# Altium schematic sheet Microcontroller_STM32F04.SchDoc
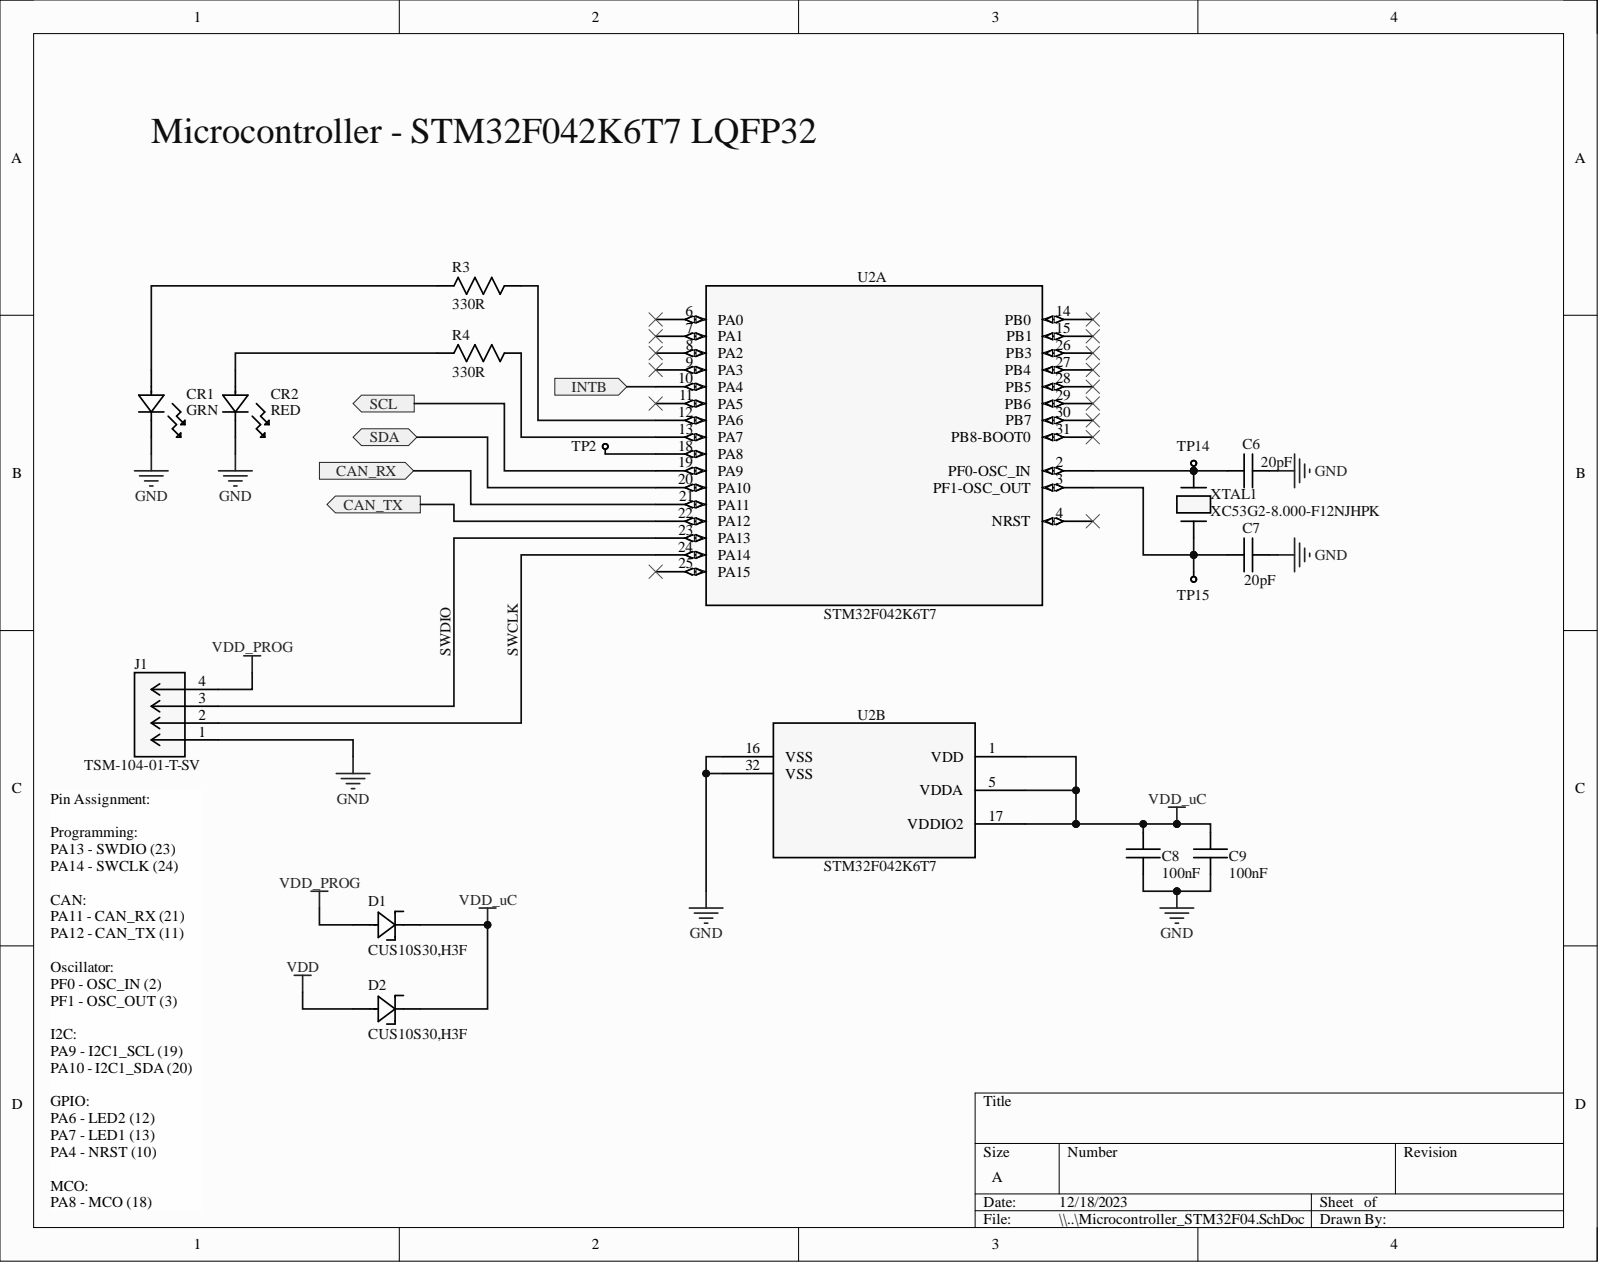
[diagram p0: Microcontroller_STM32F04.SchDoc]

## Parts
C6  20pF  [C0603]
C7  20pF  [C0603]
C8  100nF  [CAP0805]
C9  100nF  [CAP0805]
CR1  GRN  [LED_VISHAY_VLMX1300_VIS]
CR2  RED  [LED_VISHAY_VLMX1300_VIS]
D1  CUS10S30,H3F  [USC_TOS]
D2  CUS10S30,H3F  [USC_TOS]
J1  TSM-104-01-T-SV  [CONN_TSM-104-01-T-SV_SAI]
R3  330R  [R0603]
R4  330R  [R0603]
TP2  1mm Test Point  [TP_1MM]  (value not drawn)
TP14  1mm Test Point  [TP_1MM]  (value not drawn)
TP15  1mm Test Point  [TP_1MM]  (value not drawn)
U2  STM32F042K6T7  [LQFP-32]  (parts A,B)
XTAL1  XC53G2-8.000-F12NJHPK  [XTAL_NX5032_NDK]

## Ports
CAN_RX, CAN_TX, INTB, SCL, SDA

## Nets
GND: C6.1 C7.1 C8.2 C9.2 CR1.1 CR2.1 J1.1 U2.16(VSS) U2.32(VSS)
VDD: D2.2
VDD_PROG: D1.2 J1.4
VDD_uC: C8.1 C9.1 D1.1 D2.1 U2.1(VDD) U2.5(VDDA) U2.17(VDDIO2)
CAN_RX: U2.21(PA11) ; port CAN_RX
CAN_TX: U2.22(PA12) ; port CAN_TX
INTB: U2.10(PA4) ; port INTB
SCL: U2.19(PA9) ; port SCL
SDA: U2.20(PA10) ; port SDA
NetC6_2: C6.2 TP14.1 U2.2(PF0-OSC_IN) XTAL1.2
NetC7_2: C7.2 TP15.1 U2.3(PF1-OSC_OUT) XTAL1.1
NetCR1_2: CR1.2 R3.2
NetCR2_2: CR2.2 R4.2
NetJ1_2: J1.2 U2.24(PA14)
NetJ1_3: J1.3 U2.23(PA13)
NetR3_1: R3.1 U2.12(PA6)
NetR4_1: R4.1 U2.13(PA7)
NetTP2_1: TP2.1 U2.18(PA8)

Not connected: U2 4(NRST) 6(PA0) 7(PA1) 8(PA2) 9(PA3) 11(PA5) 14(PB0) 15(PB1) 25(PA15) 26(PB3) 27(PB4) 28(PB5) 29(PB6) 30(PB7) 31(PB8-BOOT0)

## Library data of the parts
C6 C7 = CL10C200JB8NNNC: Multilayer Ceramic Capacitor, 20 pF, 50 V, ± 5%, C0G (NP0), 0603 [1608 Metric]; Manufacturer: Samsung; Temperature Coefficient: 300ppm/°C; Value: 20pF 50V; Voltage: 50V; Case Code (Imperial): 0603; Case Code (Metric): 1608; Case/Package: 0603; Category: Ceramic Capacitors; Component Kind: Standard; ComponentLink1Description: https://datasheet.ciiva.com/26877/getdatasheetpartid-640634-26877974.pdf?src-supplier=Verical; ComponentLink1URL: https://datasheet.ciiva.com/26877/getdatasheetpartid-640634-26877974.pdf?src-supplier=Verical; ComponentLink2Description: https://datasheet.ciiva.com/26310/mlcc-catalogdownload2013-eng-26310315.pdf?src-supplier=Distrelec; ComponentLink2URL: https://datasheet.ciiva.com/26310/mlcc-catalogdownload2013-eng-26310315.pdf?src-supplier=Distrelec; Construction: Flat; Depth: 0.8mm; Dielectric: C0G; Height: 0.8mm; LCSC Part#: C1648; Length: 1.6mm; Library Name: Schlib1.SchLib; Manufacturer Part Number: CL10C200JB8NNNC; Max Operating Temperature: 125°C; Min Operating Temperature: -55°C; Mounting Technology: Surface Mount; Package Quantity: 1; Packaging: Tape and Reel; Pricing: 1=0.1, 10=0.041, 50=0.0238, 100=0.0184, 500=0.01386, 1000=0.01089 (USD); Radiation Hardening: No; RoHS: Yes; RoHS Compliant: Yes; Series: CL; Stock: 379574; Supplier: Digi-Key; Supplier Part Number: 1276-1187-1-ND; Thickness: 0.035inch; Tolerance: 5%; Voltage Rating: 50V; Voltage Rating (DC): 50V; Width: 0.031inch
C8 C9 = CC0805KRX7R9BB104: Multilayer Ceramic Capacitor, 0.1 uF, 50 V, ± 10%, X7R, 0805 [2012 Metric]; Manufacturer: Yageo; Insulation Resistance: 100GR; Value: 100nF 50V; Voltage: 50V; Case Code (Imperial): 0805; Case Code (Metric): 2012; Case/Package: 0805; Category: Ceramic Capacitors; Component Kind: Standard; ComponentLink1Description: https://datasheet.ciiva.com/11786/0900766b80d84f1d-11786009.pdf?src-supplier=Rs; ComponentLink1URL: https://datasheet.ciiva.com/11786/0900766b80d84f1d-11786009.pdf?src-supplier=Rs; ComponentLink2Description: https://datasheet.ciiva.com/46393/1734573-46393307.pdf?src-supplier=Element14; ComponentLink2URL: https://datasheet.ciiva.com/46393/1734573-46393307.pdf?src-supplier=Element14; ComponentLink3Description: https://datasheet.ciiva.com/155/mlccorderingcode-155930.pdf?src-supplier=Digi-Key; ComponentLink3URL: https://datasheet.ciiva.com/155/mlccorderingcode-155930.pdf?src-supplier=Digi-Key; ComponentLink4Description: https://datasheet.ciiva.com/155/mlccorderingcode-155929.pdf?src-supplier=Digi-Key; ComponentLink4URL: https://datasheet.ciiva.com/155/mlccorderingcode-155929.pdf?src-supplier=Digi-Key; ComponentLink5Description: https://datasheet.ciiva.com/155/mlccorderingcode-155931.pdf?src-supplier=Digi-Key; ComponentLink5URL: https://datasheet.ciiva.com/155/mlccorderingcode-155931.pdf?src-supplier=Digi-Key; ComponentLink6Description: https://datasheet.ciiva.com/11464/upy-gphc-x7r-6-3v-to-50v-8-11464757.pdf?src-supplier=Avnet; ComponentLink6URL: https://datasheet.ciiva.com/11464/upy-gphc-x7r-6-3v-to-50v-8-11464757.pdf?src-supplier=Avnet; ComponentLink7Description: https://datasheet.ciiva.com/28450/1489800-28450805.pdf?src-supplier=Element14; ComponentLink7URL: https://datasheet.ciiva.com/28450/1489800-28450805.pdf?src-supplier=Element14; ComponentLink8Description: https://datasheet.ciiva.com/29242/445210-da-01-en-kerko-chip-0805-x7r-0-1uf-10proz-50vg-29242074.pdf?src-supplier=Conrad; ComponentLink8URL: https://datasheet.ciiva.com/29242/445210-da-01-en-kerko-chip-0805-x7r-0-1uf-10proz-50vg-29242074.pdf?src-supplier=Conrad; Depth: 1.25mm; Dielectric: X7R; Halogen Free: Halogen Free; Height: 0.85mm; LCSC Part#: C49678; Lead Free: Lead Free; Length: 2mm; Library Name: Schlib1.SchLib; Manufacturer Part Number: CC0805KRX7R9BB104; Material: Ceramic; Max Operating Temperature: 125°C; Min Operating Temperature: -55°C; Mounting Technology: Surface Mount; Package Quantity: 4000; Packaging: Tape and Reel; Pins: 2; Pricing: 1=0.1, 10=0.07, 50=0.0418, 100=0.0329, 500=0.02428, 1000=0.0201 (USD); Radiation Hardening: No; Reach SVHC Compliant: No; RoHS: Yes; RoHS Compliant: Yes; Series: CC; Stock: 6426664; Supplier: Digi-Key; Supplier Part Number: 311-1140-1-ND; Termination: SMD/SMT; Tolerance: 10%; Voltage Rating: 50V
CR1 = VLMG1300-GS08: LED Uni-Color Yellow Green 574nm 2-Pin Chip 0603(1608Metric) T/R; Manufacturer: Vishay Semiconductors; Mfr_Name: Vishay; Color: Green,Yellow; Dominant Wavelength: 571nm; Forward Current: 20mA; Forward Voltage: 2V; Lens Style: Rectangular,Clear; Luminous Intensity: 35mcd; Number of Elements: 1; Power Dissipation: 75mW; Reverse Voltage: 5V; Type: DEV; Value: LED Green; Viewing Angle: 130°; Case Code (Imperial): 0603; Case Code (Metric): 1608; Case/Package: 0603; Category: LEDs; Component Kind: Standard; ComponentLink1Description: https://datasheet.ciiva.com/26322/vlmo1300-26322139.pdf?src-supplier=Element14; ComponentLink1URL: https://datasheet.ciiva.com/26322/vlmo1300-26322139.pdf?src-supplier=Element14; ComponentLink2Description: https://datasheet.ciiva.com/26322/vlmo1300-26322140.pdf?src-supplier=Element14; ComponentLink2URL: https://datasheet.ciiva.com/26322/vlmo1300-26322140.pdf?src-supplier=Element14; ComponentLink3Description: https://datasheet.ciiva.com/8028/vlmo1300-8028023.pdf?src-supplier=Element14; ComponentLink3URL: https://datasheet.ciiva.com/8028/vlmo1300-8028023.pdf?src-supplier=Element14; ComponentLink4Description: https://datasheet.ciiva.com/9333/vlmo1300-9333390.pdf?src-supplier=Avnet; ComponentLink4URL: https://datasheet.ciiva.com/9333/vlmo1300-9333390.pdf?src-supplier=Avnet; Depth: 0.8mm; Height: 0.8mm; Illumination Color: Green,Yellow; LCSC Part#: C4749244; Lead Free: Lead Free; Length: 1.6mm; Library Name: Schlib1.SchLib; Manufacturer Part Number: VLMG1300-GS08; Manufacturer_Part_Number: VLMG1300-GS08; Max Operating Temperature: 85°C; Min Operating Temperature: -35°C; Mounting Technology: Surface Mount; Number of LEDs: 1; Package Quantity: 1; Packaging: Tape and Reel; Pins: 2; Power Rating: 75mW; Pricing: 1=0.3, 10=0.237, 100=0.1065, 500=0.09616, 1000=0.07898 (USD); Radiation Hardening: No; Reach SVHC Compliant: Unknown; RefDes: RefDes; RoHS: Yes; RoHS Compliant: Yes; Series: CHipLED; Stock: 55201; Supplier: Digi-Key; Supplier Part Number: VLMG1300-GS08CT-ND; Test Current: 20mA; Wavelength: 571nm; Width: 0.8mm; Copyright: Copyright (C) 2023 Ultra Librarian. All rights reserved.
CR2 = VLMS1300-GS08: LED RED 0603 SMD; Manufacturer: Vishay Semiconductors; Mfr_Name: Vishay; Dominant Wavelength: 631nm; Forward Current: 20mA; Forward Voltage: 2V; Lens Style: Rectangular,Clear; Luminous Intensity: 54mcd; Number of LEDs: 1; Power Rating: 75mW; Type: DEV; Value: LED Red; Viewing Angle: 130°; Case Code (Imperial): 0603; Case Code (Metric): 1608; Case/Package: 0603; Category: LEDs; Color: Red; Component Kind: Standard; ComponentLink1Description: https://datasheet.ciiva.com/26322/vlmo1300-26322153.pdf?src-supplier=Element14; ComponentLink1URL: https://datasheet.ciiva.com/26322/vlmo1300-26322153.pdf?src-supplier=Element14; ComponentLink2Description: https://datasheet.ciiva.com/8028/vlmo1300-8028047.pdf?src-supplier=Element14; ComponentLink2URL: https://datasheet.ciiva.com/8028/vlmo1300-8028047.pdf?src-supplier=Element14; ComponentLink3Description: https://datasheet.ciiva.com/9333/vlmo1300-9333743.pdf?src-supplier=Avnet; ComponentLink3URL: https://datasheet.ciiva.com/9333/vlmo1300-9333743.pdf?src-supplier=Avnet; ComponentLink4Description: https://datasheet.ciiva.com/16510/vlmo1300-16510985.pdf?src-supplier=Future+Electronics; ComponentLink4URL: https://datasheet.ciiva.com/16510/vlmo1300-16510985.pdf?src-supplier=Future+Electronics; ComponentLink5Description: https://datasheet.ciiva.com/2114/vlmo1300-2114930.pdf?src-supplier=Digi-Key; ComponentLink5URL: https://datasheet.ciiva.com/2114/vlmo1300-2114930.pdf?src-supplier=Digi-Key; ComponentLink6Description: https://datasheet.ciiva.com/2114/vlmo1300-2114931.pdf?src-supplier=Digi-Key; ComponentLink6URL: https://datasheet.ciiva.com/2114/vlmo1300-2114931.pdf?src-supplier=Digi-Key; ComponentLink7Description: https://datasheet.ciiva.com/2114/vlmo1300-2114929.pdf?src-supplier=Digi-Key; ComponentLink7URL: https://datasheet.ciiva.com/2114/vlmo1300-2114929.pdf?src-supplier=Digi-Key; Height: 0.8mm; Illumination Color: Red; LCSC Part#: C83242; Lead Free: Lead Free; Length: 1.6mm; Library Name: Schlib1.SchLib; Manufacturer Part Number: VLMS1300-GS08; Manufacturer_Part_Number: VLMS1300-GS08; Max Operating Temperature: 85°C; Min Operating Temperature: -35°C; Mounting Technology: Surface Mount; Package Quantity: 1; Packaging: Tape and Reel; Pricing: 1=0.28, 10=0.223, 100=0.1002, 500=0.0905, 1000=0.07434 (USD); Radiation Hardening: No; Reach SVHC Compliant: Unknown; RefDes: RefDes; RoHS: Yes; RoHS Compliant: Yes; Series: CHipLED; Stock: 28800; Supplier: Digi-Key; Supplier Part Number: VLMS1300-GS08CT-ND; Test Current: 20mA; Wavelength: 631nm; Width: 0.8mm; Copyright: Copyright (C) 2023 Ultra Librarian. All rights reserved.
D1 D2 = CUS10S30,H3F: Rectifier Diode, Schottky, 1 Phase, 1 Element, 1A, 30V V(RRM), Silicon; Manufacturer: Toshiba; Mfr_Name: Toshiba Electronic Devices & Storage Corp.; Max Reverse Current: 500uA; Peak Non-Repetitive Surge Current: 5A; Type: DEV; Average Rectified Current: 1A; Case/Package: SOD; Category: Rectifier Diodes; Component Kind: Standard; ComponentLink1Description: http://toshiba.semicon-storage.com/info/lookup.jsp?pid=CUS10S30&amp;lang=en&src-supplier=Mouser; ComponentLink1URL: http://toshiba.semicon-storage.com/info/lookup.jsp?pid=CUS10S30&amp;lang=en&src-supplier=Mouser; ComponentLink2Description: http://toshiba.semicon-storage.com/info/docget.jsp?did=14077&prodName=CUS10S30&src-supplier=Avnet; ComponentLink2URL: http://toshiba.semicon-storage.com/info/docget.jsp?did=14077&prodName=CUS10S30&src-supplier=Avnet; ComponentLink3Description: https://toshiba.semicon-storage.com/info/docget.jsp?did=14077&prodName=CUS10S30&src-supplier=Digi-Key; ComponentLink3URL: https://toshiba.semicon-storage.com/info/docget.jsp?did=14077&prodName=CUS10S30&src-supplier=Digi-Key; Contact Plating: Tin,Silver; LCSC Part#: C146335; Library Name: Schlib1.SchLib; Manufacturer Part Number: CUS10S30,H3F; Manufacturer_Part_Number: CUS10S30,H3F; Max Operating Temperature: 125°C; Max Reverse Voltage (DC): 30V; Min Operating Temperature: -55°C; Mounting Technology: Surface Mount; Packaging: Cut Tape; Pins: 2; Pricing: 1=0.35, 10=0.243, 100=0.1228, 500=0.1002, 1000=0.07434 (USD); RefDes: RefDes; RoHS: Yes; RoHS Compliant: Yes; Series: -; Stock: 308991; Supplier: Digi-Key; Supplier Part Number: CUS10S30H3FCT-ND; Value: CUS10S30,H3F; Copyright: Copyright (C) 2023 Ultra Librarian. All rights reserved.
J1 = TSM-104-01-T-SV: Conn Unshrouded Header HDR 4 POS 2.54mm Solder ST Top Entry SMD Tube; Manufacturer: Samtec; Mfr_Name: Samtec Inc; Gender: Male; Max Current Rating: 7A; Max Voltage Rating (AC): 475V; Number of Contacts: 4; Category: Headers and Wire Housings; Color: Black; Component Kind: Standard; ComponentLink1Description: https://datasheet.ciiva.com/739/tsm-1xx-xx-xxx-sv-xx-xxx-xx-mkt-739625.pdf?src-supplier=Digi-Key; ComponentLink1URL: https://datasheet.ciiva.com/739/tsm-1xx-xx-xxx-sv-xx-xxx-xx-mkt-739625.pdf?src-supplier=Digi-Key; Connector Type: Header; Contact Finish - Mating: TIN; Contact Material: Bronze; Contact Mating Length: 0.23inch; Contact Plating: Tin; Contact Shape: Square; Contact Type: Male Pin; Depth: 2.54mm; Fastening Type: Pull; Flammability Rating: UL94 V-0; Housing Color: Black; Housing Material: Polymer; Insulation Color: Black; Insulation Material: Polymer; LCSC Part#: C3335977; Lead Free: Lead Free; Length: 10.16mm; Library Name: Schlib1.SchLib; Manufacturer Part Number: TSM-104-01-T-SV; Manufacturer_Part_Number: TSM-104-01-T-SV; Max Operating Temperature: 105°C; Min Operating Temperature: -55°C; Mounting Technology: Surface Mount; Number of Positions: 4; Number of Rows: 1; Orientation: Straight; Package Quantity: 1; Packaging: Rail/Tube; Pitch: 2.54mm; Pricing: 1=0.65, 10=0.564, 100=0.4646, 500=0.404, 1000=0.3333, 5000=0.2929, 10000=0.2727 (USD); Radiation Hardening: No; Reach SVHC Compliant: No; RefDes: RefDes; RoHS: Yes; RoHS Compliant: Yes; Series: TSM; Stock: 1177; Supplier: Digi-Key; Supplier Part Number: SAM8983-ND; Termination: Solder; Type: DEV; Value: TSM-104-01-T-SV; Copyright: Copyright (C) 2023 Ultra Librarian. All rights reserved.
R3 R4 = RC0603JR-13330RL: Res Thick Film 0603 330 Ohm 5% 0.1W(1/10W) ±100ppm/C Pad SMD T/R; Manufacturer: Yageo; Power Rating: 100mW; Temperature Coefficient: 100ppm/°C; Voltage Rating: 50V; Case Code (Imperial): 0603; Case Code (Metric): 1608; Case/Package: 0603; Category: Chip SMD Resistors; Component Kind: Standard; ComponentLink1Description: http://www.mouser.com/ds/2/447/RC0603-272842.pdf?src-supplier=Mouser; ComponentLink1URL: http://www.mouser.com/ds/2/447/RC0603-272842.pdf?src-supplier=Mouser; ComponentLink2Description: https://datasheet.octopart.com/RC0603JR-13330RL-Yageo-datasheet-164759695.pdf?src-supplier=Future+Electronics; ComponentLink2URL: https://datasheet.octopart.com/RC0603JR-13330RL-Yageo-datasheet-164759695.pdf?src-supplier=Future+Electronics; ComponentLink3Description: http://www.yageo.com/pdf/PYu-RC0603_51_RoHS_L_4.pdf?src-supplier=Avnet; ComponentLink3URL: http://www.yageo.com/pdf/PYu-RC0603_51_RoHS_L_4.pdf?src-supplier=Avnet; Contact Plating: Tin,Lead; Height: 0.45mm; LCSC Part#: C3995110; Lead Free: Lead Free; Length: 1.6mm; Library Name: Schlib1.SchLib; Manufacturer Part Number: RC0603JR-13330RL; Max Operating Temperature: 155°C; Min Operating Temperature: -55°C; Packaging: Tape and Reel; Pricing: 1=0.1, 10=0.015, 50=0.0092, 100=0.0076, 500=0.00512, 1000=0.00437, 5000=0.00314, 10000=0.00275 (USD); RoHS: Yes; RoHS Compliant: Yes; Series: RC; Stock: 83710; Supplier: Digi-Key; Supplier Part Number: 13-RC0603JR-13330RLCT-ND; Termination: SMD/SMT; Tolerance: 5%; Value: 330R; Width: 0.8mm
TP2 TP14 TP15 = TestPoint_1mm: Component Kind: Standard; Library Name: Schlib1.SchLib
U2 = STM32F042K6T7: ARM® Cortex®-M0 STM32 F0 Microcontroller IC 32-Bit 48MHz 32KB (32K x 8) FLASH 32-LQFP (7x7); Manufacturer: STMicroelectronics; Core Architecture: ARM; Data Bus Width: 32b; Interface: I2C,SPI,USB,CAN,HDMI,IrDA,UART,USART,LIN,I2S; Max Power Dissipation: 351mW; Max Supply Voltage: 3.6V; Min Supply Voltage: 2V; Number of I/Os: 26; Number of Timers/Counters: 6; Oscillator Type: Internal; Peripherals: POR,PWM,WDT,DMA; RAM Size: 6KB; Watchdog Timer: Yes; Case/Package: LQFP; Category: Microcontrollers; Clock Frequency: 48MHz; Component Kind: Standard; ComponentLink1Description: http://www.farnell.com/datasheets/2281753.pdf?src-supplier=Farnell; ComponentLink1URL: http://www.farnell.com/datasheets/2281753.pdf?src-supplier=Farnell; ComponentLink2Description: http://www.mouser.com/ds/2/389/stm32f042c4-956225.pdf?src-supplier=Mouser; ComponentLink2URL: http://www.mouser.com/ds/2/389/stm32f042c4-956225.pdf?src-supplier=Mouser; ComponentLink3Description: http://www.st.com/content/ccc/resource/technical/document/datasheet/52/ad/d0/80/e6/be/40/ad/DM00105814.pdf/files/DM00105814.pdf/jcr:content/translations/en.DM00105814.pdf?src-supplier=Digi-Key; ComponentLink3URL: http://www.st.com/content/ccc/resource/technical/document/datasheet/52/ad/d0/80/e6/be/40/ad/DM00105814.pdf/files/DM00105814.pdf/jcr:content/translations/en.DM00105814.pdf?src-supplier=Digi-Key; ComponentLink4Description: http://www.st.com/st-web-ui/static/active/en/resource/technical/document/datasheet/DM00105814.pdf?src-supplier=Avnet; ComponentLink4URL: http://www.st.com/st-web-ui/static/active/en/resource/technical/document/datasheet/DM00105814.pdf?src-supplier=Avnet; ComponentLink5Description: http://www.farnell.com/datasheets/1886881.pdf?src-supplier=Element14; ComponentLink5URL: http://www.farnell.com/datasheets/1886881.pdf?src-supplier=Element14; ComponentLink6Description: http://www.farnell.com/datasheets/2281753.pdf?src-supplier=Newark; ComponentLink6URL: http://www.farnell.com/datasheets/2281753.pdf?src-supplier=Newark; ComponentLink7Description: https://datasheet.octopart.com/STM32F042K6T7-STMicroelectronics-datasheet-62336640.pdf?src-supplier=LKR; ComponentLink7URL: https://datasheet.octopart.com/STM32F042K6T7-STMicroelectronics-datasheet-62336640.pdf?src-supplier=LKR; ComponentLink8Description: https://datasheet.octopart.com/STM32F042K6T7-STMicroelectronics-datasheet-82511004.pdf?src-supplier=SHENGYU+ELECTRONICS; ComponentLink8URL: https://datasheet.octopart.com/STM32F042K6T7-STMicroelectronics-datasheet-82511004.pdf?src-supplier=SHENGYU+ELECTRONICS; Frequency: 48MHz; LCSC Part#: C1337340; Lead Free: Lead Free; Library Name: Schlib1.SchLib; Manufacturer Part Number: STM32F042K6T7; Manufacturer_Part_Number: STM32F042K6T7; Max Operating Temperature: 105°C; Memory Format: FLASH; Memory Size: 32KB; Mfr_Name: STMicroelectronics; Min Operating Temperature: -40°C; Number of Programmable I/O: 26; Packaging: Tray; Pins: 32; Pricing: 1=4.08, 10=3.669, 25=3.4684, 80=3.00588, 250=2.85172, 500=2.55882, 1000=2.15805, 2500=2.05014, 5000=1.97307 (USD); Radiation Hardening: No; Reach SVHC Compliant: No; RefDes: RefDes; RoHS: Yes; RoHS Compliant: Yes; Series: STM32 F0; Stock: 2881; Supplier: Digi-Key; Supplier Part Number: 497-18516-ND; Type: DEV; Value: STM32F042K6T7; Copyright: Copyright (C) 2023 Ultra Librarian. All rights reserved.
XTAL1 = XC53G2-8.000-F12NJHP: CRYSTAL 8MHZ 12PF SMD; Mfr_Name: HCI; Component Kind: Standard; LCSC Part#: C2842269; Library Name: Schlib1.SchLib; Manufacturer_Part_Number: XC53G2-8.000-F12NJHPK; RefDes: RefDes; Type: DEV; Value: XC53G2-8.000-F12NJHPK; Copyright: Copyright (C) 2023 Ultra Librarian. All rights reserved.
